# Altium schematic sheet Oscillator.SchDoc
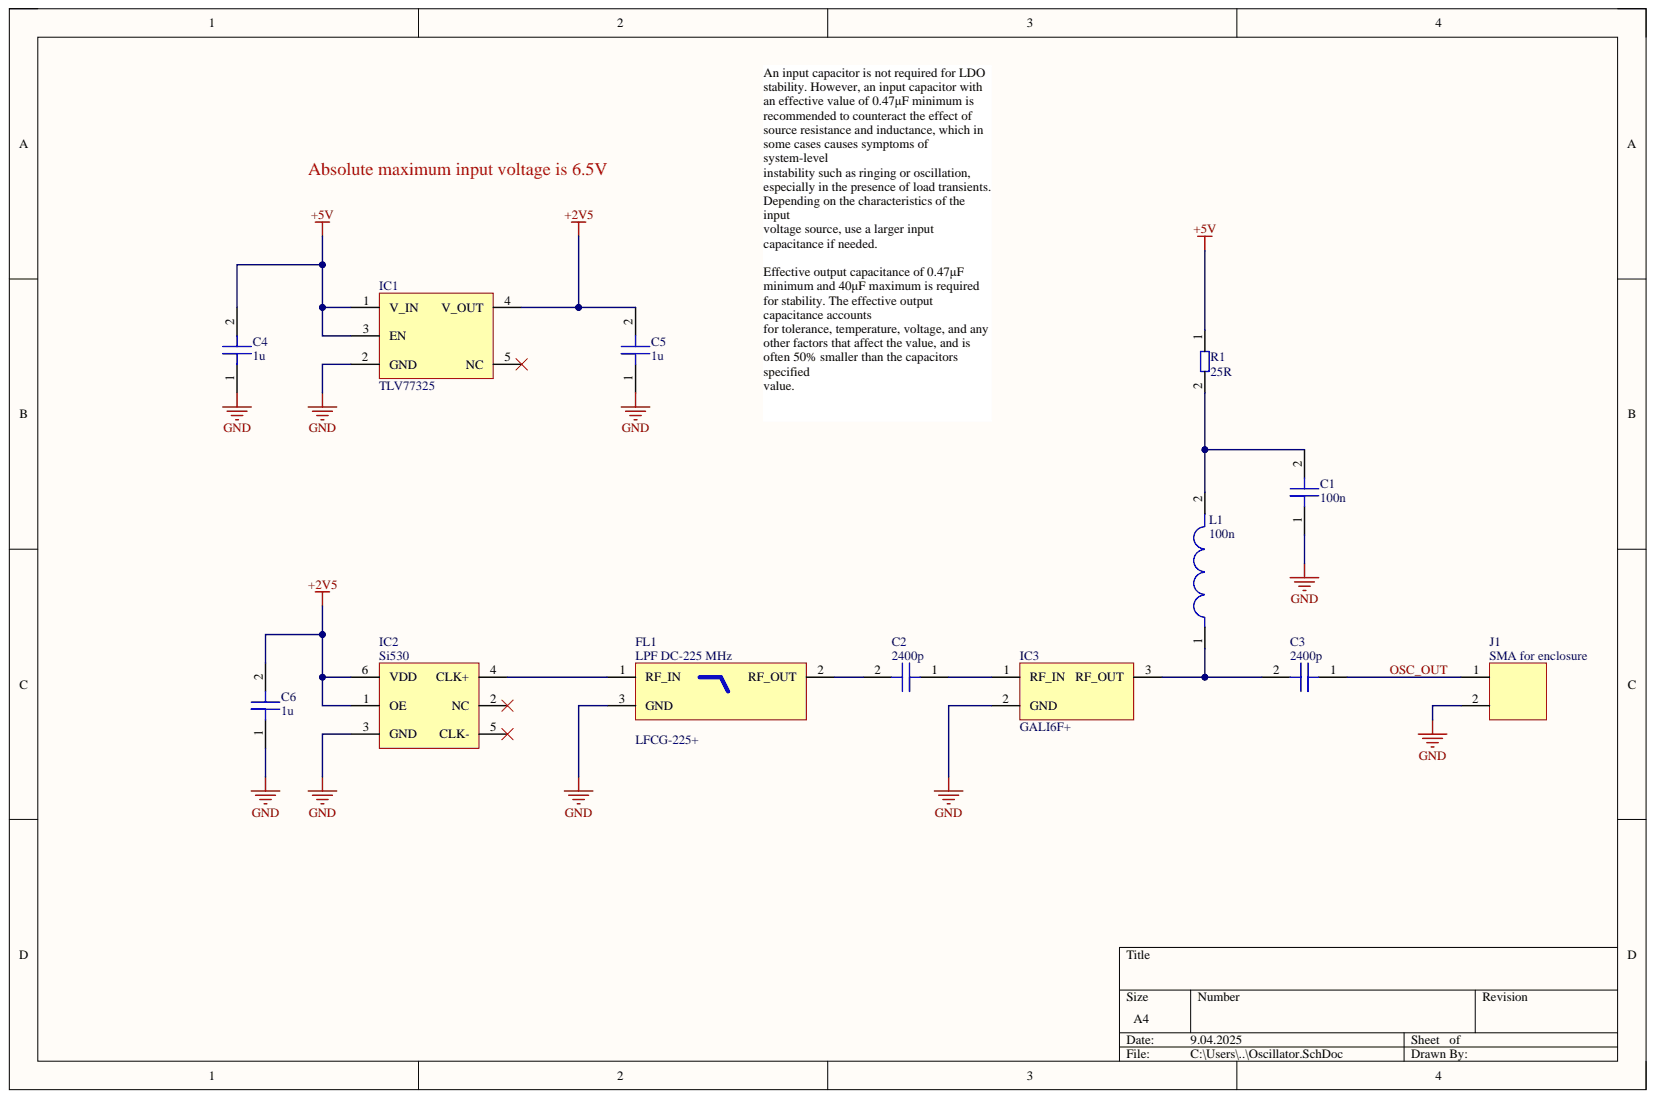
[diagram p0: Oscillator.SchDoc]

## Parts
C1  100n  [0603_CAP]
C2  2400p  [0603_CAP]
C3  2400p  [0603_CAP]
C4  1u  [0603_CAP]
C5  1u  [0603_CAP]
C6  1u  [0603_CAP]
FL1  LFCG-225+
IC1  TLV77325  [IC_TLV77325PDBVR_TEXAS_INSTRUMENTS]
IC2  Si530
IC3  GALI6F+  [IC_GALI6F+_MINI_CIRCUITS]
J1  SMA for enclosure
L1  100n  [0603_CAP]
R1  25R  [0603_RESISTOR]

## Nets
+2V5: C5.2 C6.2 IC1.4(V_OUT) IC2.1(OE) IC2.6(VDD)
+5V: C4.2 IC1.1(V_IN) IC1.3(EN) R1.1
GND: C1.1 C4.1 C5.1 C6.1 FL1.3 IC1.2(GND) IC2.3(GND) IC3.2 J1.2
OSC_OUT: C3.1 J1.1
NetC1_2: C1.2 L1.2 R1.2
NetC2_1: C2.1 IC3.1
NetC2_2: C2.2 FL1.2
NetC3_2: C3.2 IC3.3 L1.1
NetFL1_1: FL1.1 IC2.4(CLK+)

Not connected: IC1 5(NC); IC2 2(NC) 5(CLK-)

## Library data of the parts
C1-C6 = CAP_0603_generic: Component Kind: Standard; Library Name: Radolovic_SCH_Library.SchLib
  C1: Value: 100n
  C2 C3: Value: 2400p
  C4-C6: Value: 1u
FL1 = FL_LFCG-225+_MINI_CIRCUITS: LFCG-225+ Low Pass Filter; Component Kind: Standard; Library Name: Radolovic_SCH_Library.SchLib
IC1 = IC_TLV77325_TEXAS_INSTRUMENTS: LDO Voltage Regulators 300-mA high-PSRR low -IQ low-dropout regulator; Component Kind: Standard; Library Name: Radolovic_SCH_Library.SchLib
IC2 = IC_SI530_SILICON_LABS: Component Kind: Standard; Library Name: Radolovic_SCH_Library.SchLib
IC3 = IC_GALI6F+_MINI_CIRCUITS: RF Amplifier ML AMPL / SURF MT / RoHS; Component Kind: Standard; Library Name: Radolovic_SCH_Library.SchLib
J1 = HEADER_1x2_0.254MM: Component Kind: Standard; Value: SMA for enclosure; Library Name: Radolovic_SCH_Library.SchLib
L1 = IND_air_0603_generic: Component Kind: Standard; Value: 100n; Library Name: Radolovic_SCH_Library.SchLib
R1 = RES_0603_generic: 3/4W; Component Kind: Standard; Value: 25R; Library Name: Radolovic_SCH_Library.SchLib
